# Altium schematic sheet Sheet1.SchDoc
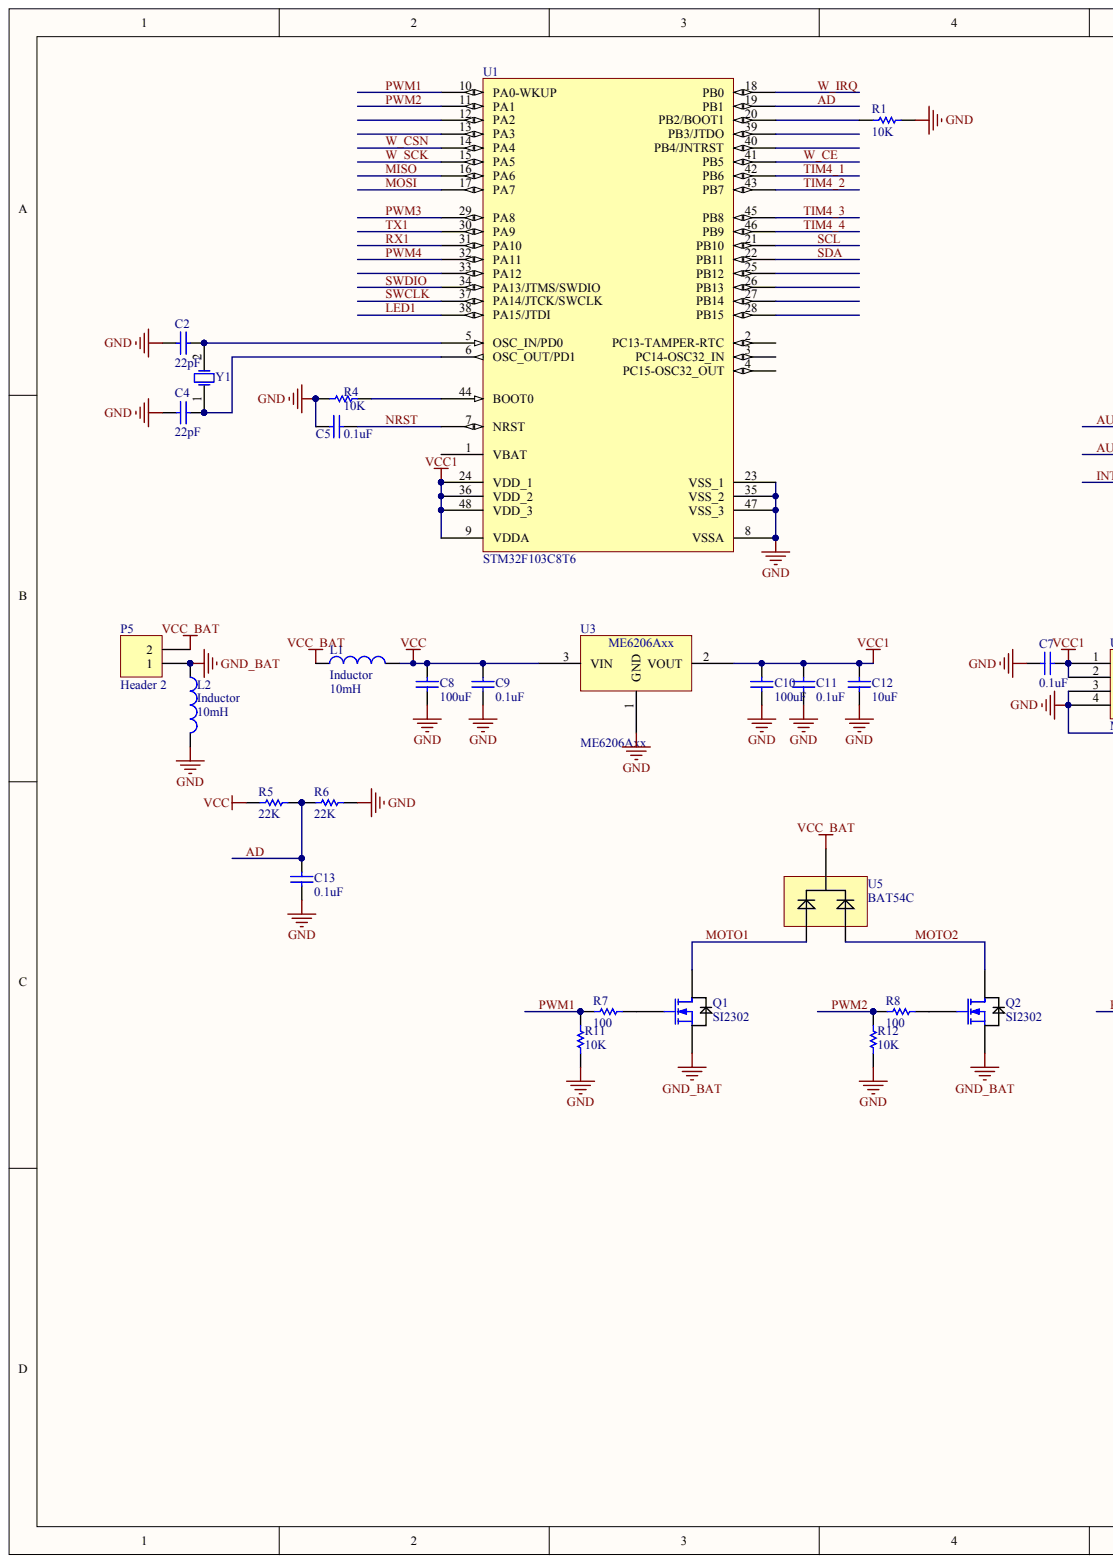
[diagram p0: Sheet1.SchDoc, left]
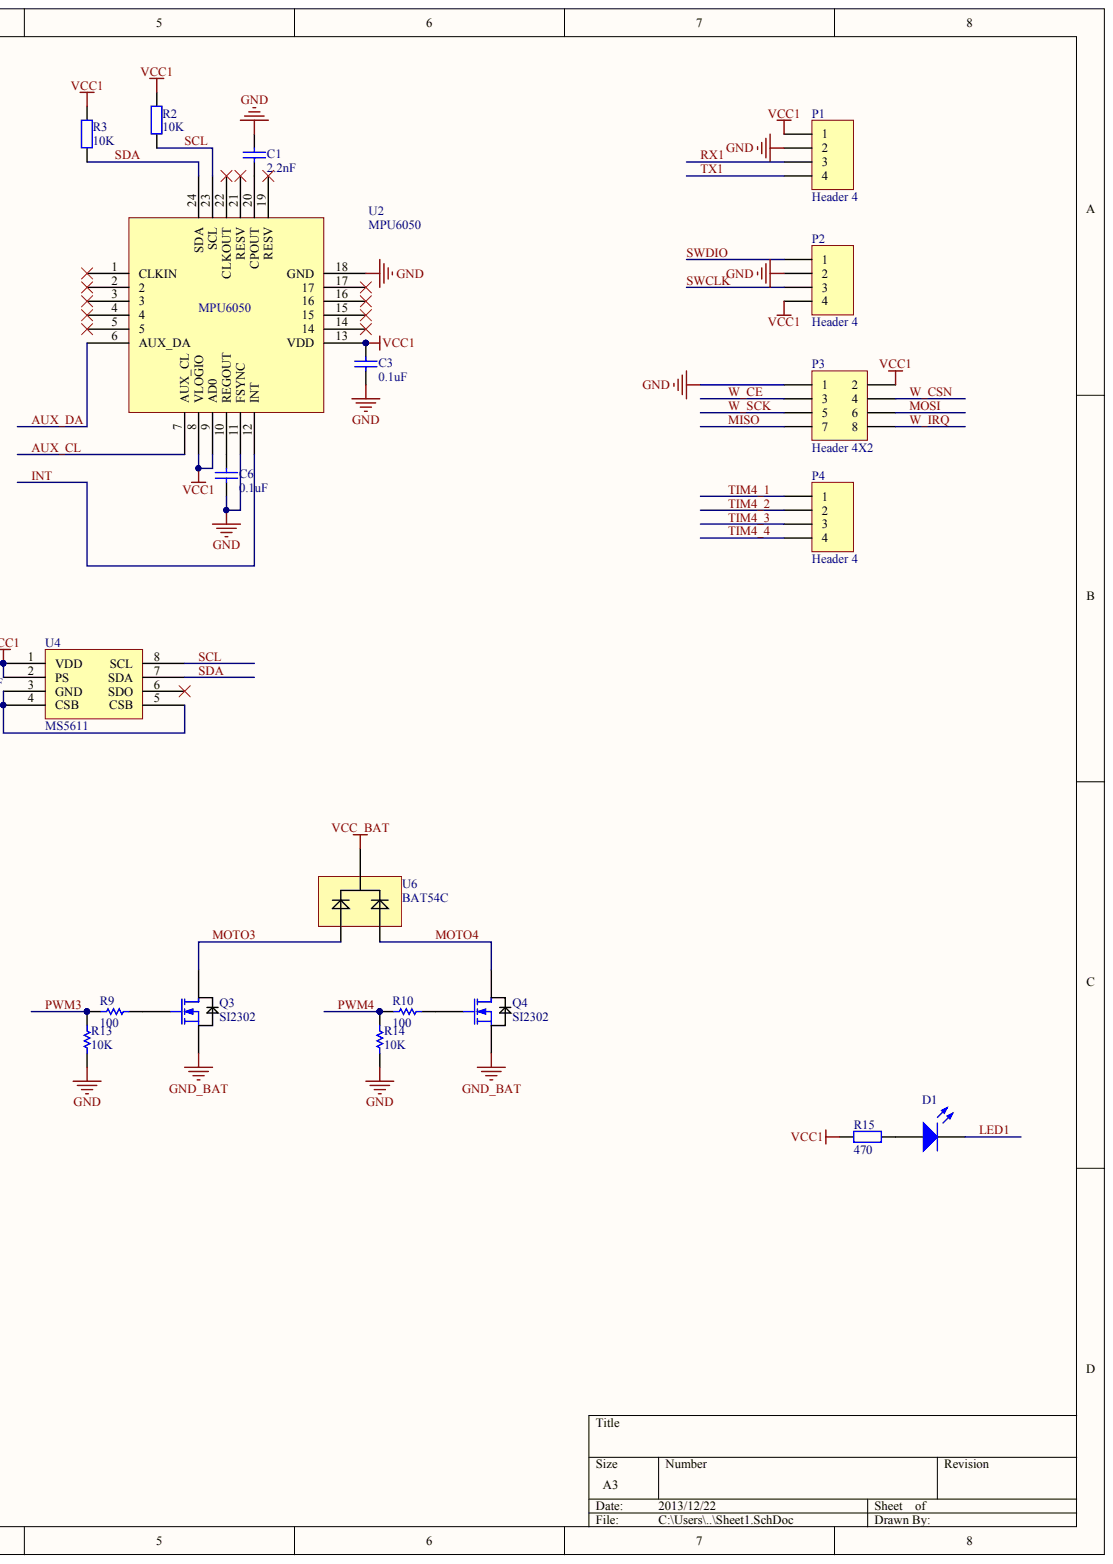
[diagram p1: Sheet1.SchDoc, right]

## Parts
C1  value 2.2nF  (hidden comment: Cap)
C2  value 22pF  (hidden comment: Cap)
C3  value 0.1uF  (hidden comment: Cap)
C4  value 22pF  (hidden comment: Cap)
C5  value 0.1uF  (hidden comment: Cap)
C6  value 0.1uF  (hidden comment: Cap)
C7  value 0.1uF  (hidden comment: Cap)
C8  value 100uF  (hidden comment: Cap)
C9  value 0.1uF  (hidden comment: Cap)
C10  value 100uF  (hidden comment: Cap)
C11  value 0.1uF  (hidden comment: Cap)
C12  value 10uF  (hidden comment: Cap)
C13  value 0.1uF  (hidden comment: Cap)
D1  LED1
L1  Inductor  value 10mH
L2  Inductor  value 10mH
P1  Header 4
P2  Header 4
P3  Header 4X2
P4  Header 4
P5  Header 2
Q1  SI2302
Q2  SI2302
Q3  SI2302
Q4  SI2302
R1  value 10K  (hidden comment: Res1)
R2  value 10K  (hidden comment: Res2)
R3  value 10K  (hidden comment: Res2)
R4  value 10K  (hidden comment: Res1)
R5  value 22K  (hidden comment: Res1)
R6  value 22K  (hidden comment: Res1)
R7  value 100  (hidden comment: Res1)
R8  value 100  (hidden comment: Res1)
R9  value 100  (hidden comment: Res1)
R10  value 100  (hidden comment: Res1)
R11  value 10K  (hidden comment: Res1)
R12  value 10K  (hidden comment: Res1)
R13  value 10K  (hidden comment: Res1)
R14  value 10K  (hidden comment: Res1)
R15  value 470  (hidden comment: Res2)
U1  STM32F103C8T6
U2  MPU6050
U3  ME6206Axx
U4  MS5611
U5  BAT54C
U6  BAT54C
Y1  XTAL  (value not drawn)

## Nets
GND: C1.2 C2.1 C3.1 C4.1 C5.2 C6.1 C7.2 C8.2 C9.2 C10.2 C11.2 C12.2 C13.1 L2.2 P1.2 P2.2 P3.1 R1.2 R4.2 R6.1 R11.1 R12.1 R13.1 R14.1 U1.8(VSSA) U1.23(VSS_1) U1.35(VSS_2) U1.47(VSS_3) U2.11(FSYNC) U2.18(GND) U3.1 U4.3(GND) U4.4(CSB) U4.5(CSB)
GND_BAT: L2.1 P5.1 Q1.2 Q2.2 Q3.2 Q4.2
VCC: C8.1 C9.1 L1.2 R5.2 U3.3
VCC1: C3.2 C7.1 C10.1 C11.1 C12.1 P1.1 P2.4 P3.2 R2.1 R3.1 R15.1 U1.9(VDDA) U1.24(VDD_1) U1.36(VDD_2) U1.48(VDD_3) U2.8(VLOGIO) U2.9(AD0) U2.13(VDD) U3.2 U4.1(VDD) U4.2(PS)
VCC_BAT: L1.1 P5.2 U5.3 U6.3
AD: C13.2 R5.1 R6.2 U1.19(PB1)
AUX_CL: U2.7(AUX_CL)
AUX_DA: U2.6(AUX_DA)
INT: U2.12(INT)
LED1: D1.2 U1.38(PA15/JTDI)
MISO: P3.7 U1.16(PA6)
MOSI: P3.6 U1.17(PA7)
MOTO1: Q1.3 U5.1
MOTO2: Q2.3 U5.2
MOTO3: Q3.3 U6.1
MOTO4: Q4.3 U6.2
NRST: C5.1 U1.7(NRST)
PWM1: R7.2 R11.2 U1.10(PA0-WKUP)
PWM2: R8.2 R12.2 U1.11(PA1)
PWM3: R9.2 R13.2 U1.29(PA8)
PWM4: R10.2 R14.2 U1.32(PA11)
RX1: P1.3 U1.31(PA10)
SCL: R2.2 U1.21(PB10) U2.23(SCL) U4.8(SCL)
SDA: R3.2 U1.22(PB11) U2.24(SDA) U4.7(SDA)
SWCLK: P2.3 U1.37(PA14/JTCK/SWCLK)
SWDIO: P2.1 U1.34(PA13/JTMS/SWDIO)
TIM4_1: P4.1 U1.42(PB6)
TIM4_2: P4.2 U1.43(PB7)
TIM4_3: P4.3 U1.45(PB8)
TIM4_4: P4.4 U1.46(PB9)
TX1: P1.4 U1.30(PA9)
W_CE: P3.3 U1.41(PB5)
W_CSN: P3.4 U1.14(PA4)
W_IRQ: P3.8 U1.18(PB0)
W_SCK: P3.5 U1.15(PA5)
NetC1_1: C1.1 U2.20(CPOUT)
NetC2_2: C2.2 U1.5(OSC_IN/PD0) Y1.2
NetC4_2: C4.2 U1.6(OSC_OUT/PD1) Y1.1
NetC6_2: C6.2 U2.10(REGOUT)
NetD1_1: D1.1 R15.2
NetQ1_1: Q1.1 R7.1
NetQ2_1: Q2.1 R8.1
NetQ3_1: Q3.1 R9.1
NetQ4_1: Q4.1 R10.1
NetR1_1: R1.1 U1.20(PB2/BOOT1)
NetR4_1: R4.1 U1.44(BOOT0)

Not connected: U1 1(VBAT) 2(PC13-TAMPER-RTC) 3(PC14-OSC32_IN) 4(PC15-OSC32_OUT) 12(PA2) 13(PA3) 25(PB12) 26(PB13) 27(PB14) 28(PB15) 33(PA12) 39(PB3/JTDO) 40(PB4/JNTRST); U2 1(CLKIN) 2 3 4 5 14 15 16 17 19(RESV) 21(RESV) 22(CLKOUT); U4 6(SDO)

## Library data of the parts
L1 L2 = (no symbol name): Code_IEC: 1005; Published: 8-Jun-2000; LatestRevisionDate: 29-May-2009; LatestRevisionNote: IPC-7351 Footprint Added.; PackageReference: 0402-A; PackageDescription: Chip Inductor; Code_JEITA: 0402; Publisher: Altium Limited
C1-C13 D1 P1-P5 Q1-Q4 R1-R15 U1-U6 Y1 = (no symbol name)
  C1-C13: Published: 8-Jun-2000; LatestRevisionDate: 17-Jul-2002; LatestRevisionNote: Re-released for DXP Platform.; PackageReference: RAD-0.3
  D1: Published: 8-Jun-2000; LatestRevisionDate: 08-Jul-2005; LatestRevisionNote: Mech Layer 1 removed.; PackageReference: LED-1
  P1-P5: LatestRevisionDate: 17-Jul-2002; LatestRevisionNote: Re-released for DXP Platform.
  R1 R4-R14: Published: 8-Jun-2000; LatestRevisionDate: 17-Jul-2002; LatestRevisionNote: Re-released for DXP Platform.; PackageReference: AXIAL-0.3
  R2 R3 R15: Published: 8-Jun-2000; LatestRevisionDate: 17-Jul-2002; LatestRevisionNote: Re-released for DXP Platform.; PackageReference: AXIAL-0.4
  U1: Published: *; DatasheetVersion: *; PackageVersion: *; PackageReference: *; PackageDescription: *; ComponentLink1Description: *; ComponentLink1URL: *; ComponentLink2Description: *; ComponentLink2URL: *; Publisher: *
  Y1: Published: 8-Jun-2000; LatestRevisionDate: 17-Jul-2002; LatestRevisionNote: Re-released for DXP Platform.; PackageReference: R38
  C1-C13 D1 P1-P5 R1-R15 Y1: Publisher: Altium Limited
